# Altium schematic sheet PWR_FPGA.SchDoc(PWR_FPGA)
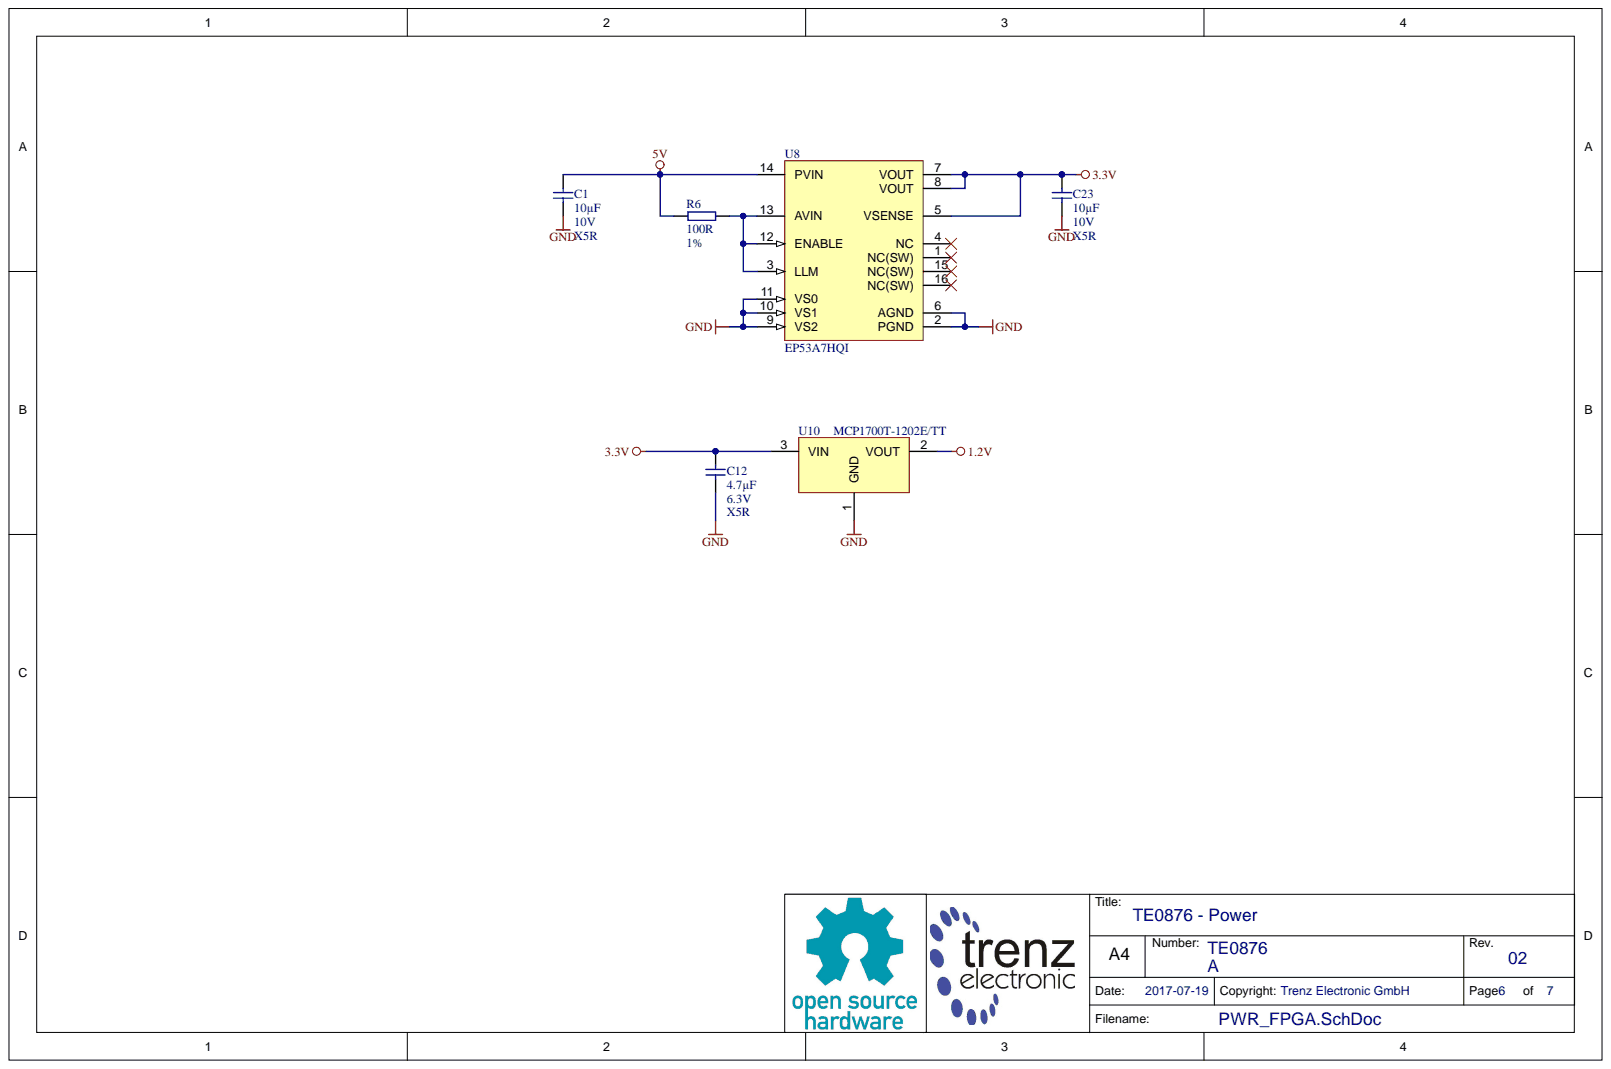
[diagram p0: PWR_FPGA.SchDoc]

## Parts
C1  10µF  Voltage: 10V  Technology: X5R  [CAPC2012X135N]
C12  4.7µF  Voltage: 6.3V  Technology: X5R  [CAPC1005X60N]
C23  10µF  Voltage: 10V  Technology: X5R  [CAPC2012X135N]
R6  100R  Tolerance: 1%  [RESC1005X40N]
U8  EP53A7HQI  [EP53F8QI]
U10  MCP1700T-1202E/TT  [SOT95P237X112-3N]

## Nets
1.2V: U10.2
3.3V: C12.1 C23.1 U8.5(VSENSE) U8.7(VOUT) U8.8(VOUT) U10.3
5V: C1.1 R6.1 U8.14(PVIN)
GND: C1.2 C12.2 C23.2 U8.2(PGND) U8.6(AGND) U8.9(VS2) U8.10(VS1) U8.11(VS0) U10.1
NetR6_2: R6.2 U8.3(LLM) U8.12(ENABLE) U8.13(AVIN)

Not connected: U8 1(NC(SW)) 4(NC) 15(NC(SW)) 16(NC(SW))

## Library data of the parts
C1 C23 = 28014: KON 10µF 10V X5R 10% SMD; Value: 10u; Mixed: SMT; Database Table Name: C_2012_0805; Tolerance: 10%; Library Name: TE.DbLib; Component Kind: Standard; Case: 2012 (0805); AN: 28014
C12 = 24719: KON 4.7µF 6.3V X5R SMD 20%; Value: 4.7u; Preferred: Yes; Mixed: SMT; Database Table Name: C_1005_0402; Tolerance: 20%; Library Name: TE.DbLib; Component Kind: Standard; Case: 1005 (0402); AN: 24719
R6 = 22507: WID SMD 100R 1% 0,063W; Value: 100; Preferred: Yes; Mixed: SMT; Database Table Name: R_1005_0402; Technology: TK100; Library Name: TE.DbLib; Component Kind: Standard; Case: 1005 (0402); AN: 22507
U8 = 27996: Trenz-Stock: Yes; Mixed: SMT; Database Table Name: DCDC; Preferred: Yes; Library Name: TE.DbLib; H-ART: EP53A7HQI; Description: EP53A7HQI; Component Kind: Standard; Case: QFN16; AN: 27996; HelpURL: B:\AD\adlib\datasheet\EP53A7HQI
U10 = 26755: Trenz-Stock: Yes; Mixed: SMT; Database Table Name: LREG; Library Name: TE.DbLib; H-ART: MCP1700T-1202E/TT; Description: MCP1700T-1202E/TT; Component Kind: Standard; Case: SOT23-3; AN: 26755; HelpURL: B:\ad\adlib\datasheet\MCP1700
